# Altium schematic sheet Modified_schematic.SchDoc(Modified_schematic)
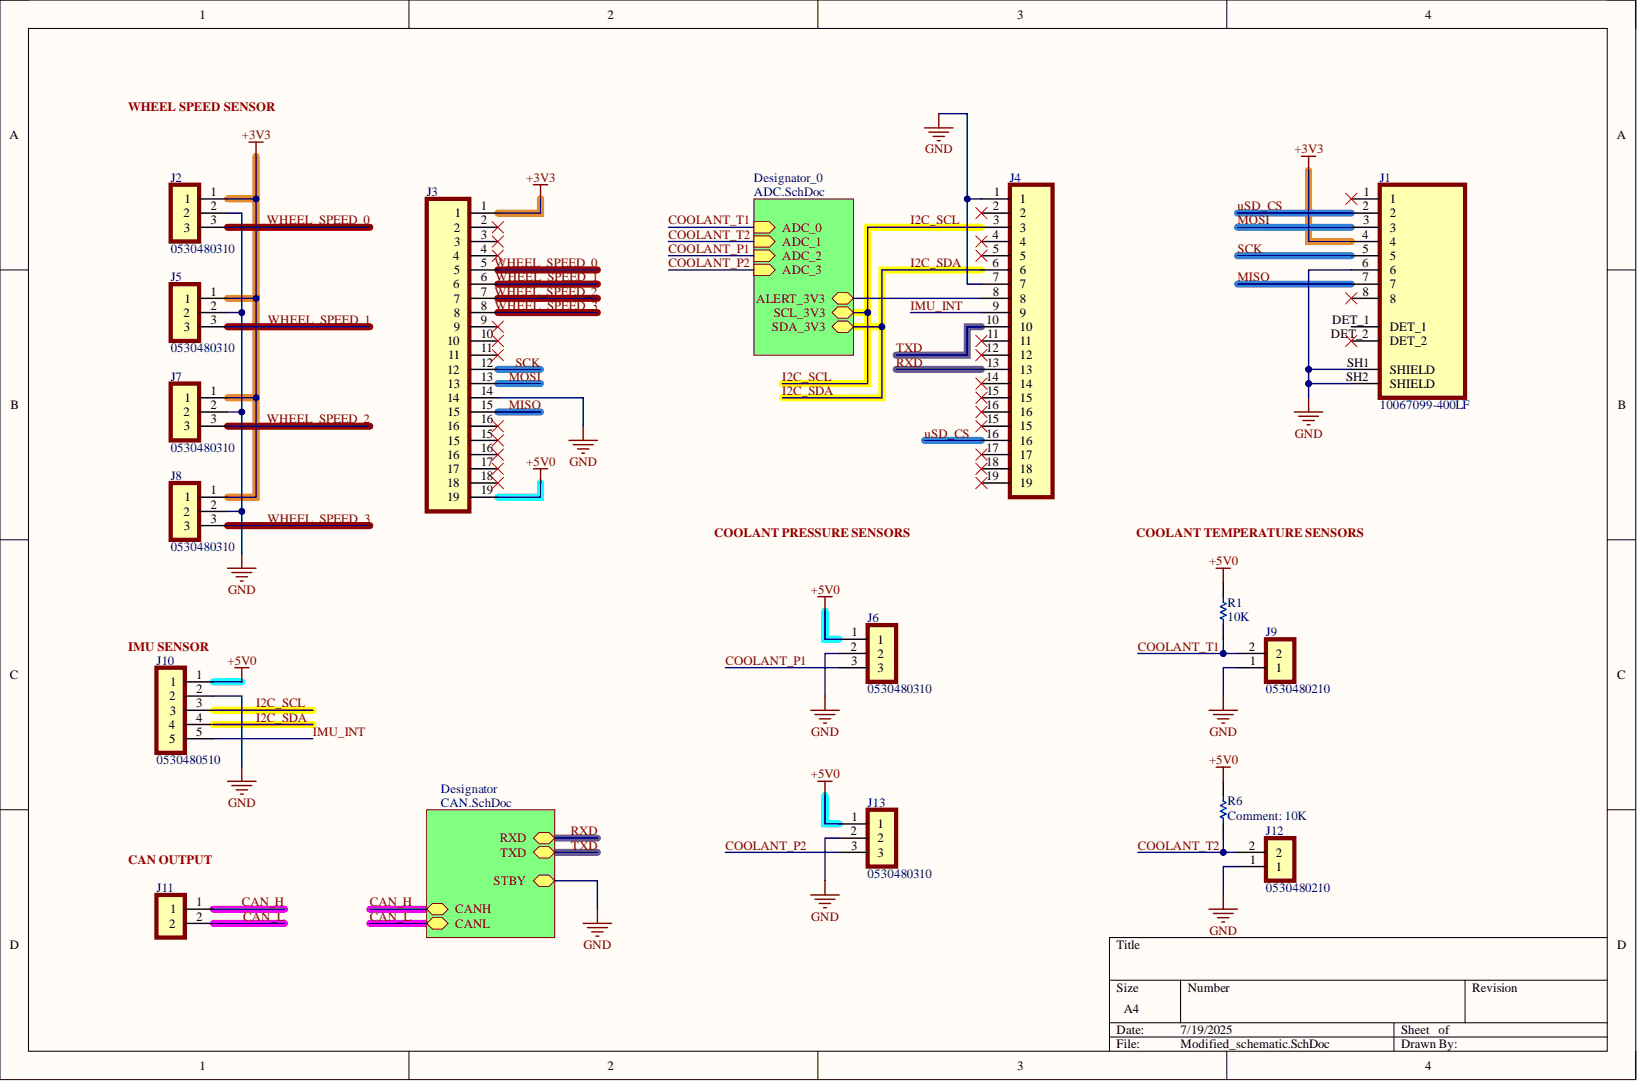
[diagram p0: Modified_schematic.SchDoc]

## Parts
J1  10067099-400LF
J2  0530480310
J3  PPTC191LFBN-RC  (value not drawn)
J4  PPTC191LFBN-RC  (value not drawn)
J5  0530480310
J6  0530480310
J7  0530480310
J8  0530480310
J9  0530480210
J10  0530480510
J11  0530480210
J12  0530480210
J13  0530480310
R1  10K
R6  10K

## Nets
+3V3: J1.4 J2.1 J3.1 J5.1 J7.1 J8.1
+5V0: J3.19 J6.1 J10.1 J13.1 R1.2 R6.2
GND: J1.6 J1.SH1(SHIELD) J1.SH2(SHIELD) J2.2 J3.14 J4.1 J4.7 J5.2 J6.2 J7.2 J8.2 J9.1 J10.2 J12.1 J13.2
CAN_H: J11.1
CAN_L: J11.2
COOLANT_P1: J6.3
COOLANT_P2: J13.3
COOLANT_T1: J9.2 R1.1
COOLANT_T2: J12.2 R6.1
I2C_SCL: J4.3 J10.3
I2C_SDA: J4.6 J10.4
IMU_INT: J4.9 J10.5
MISO: J1.7 J3.15
MOSI: J1.3 J3.13
RXD: J4.13
SCK: J1.5 J3.12
TXD: J4.10
uSD_CS: J1.2 J4.16
WHEEL_SPEED_0: J2.3 J3.5
WHEEL_SPEED_1: J3.6 J5.3
WHEEL_SPEED_2: J3.7 J7.3
WHEEL_SPEED_3: J3.8 J8.3
NetJ1_1: J1.1
NetJ1_8: J1.8
NetJ1_DET_1: J1.DET_1
NetJ1_DET_2: J1.DET_2
NetJ3_2: J3.2
NetJ3_3: J3.3
NetJ3_4: J3.4
NetJ3_9: J3.9
NetJ3_10: J3.10
NetJ3_11: J3.11
NetJ3_15: J3.15
NetJ3_16: J3.16
NetJ3_17: J3.17
NetJ3_18: J3.18
NetJ4_2: J4.2
NetJ4_4: J4.4
NetJ4_5: J4.5
NetJ4_8: J4.8
NetJ4_11: J4.11
NetJ4_12: J4.12
NetJ4_14: J4.14
NetJ4_15: J4.15
NetJ4_16: J4.16
NetJ4_17: J4.17
NetJ4_18: J4.18
NetJ4_19: J4.19

## Library data of the parts
J1 = CONN,microSD,SMD: 10 (8 + 2) Position Card Connector Secure Digital - microSD™ Surface Mount, Right Angle Gold; Manufacturer: Amphenol ICC (FCI); Vendor Part Number: 609-10067099-400LFCT-ND; Vendor: Digikey; Component Kind: Standard; Datasheet: https://www.amphenol-cs.com/media/wysiwyg/files/drawing/10067099.pdf; Library Name: Schlib1.SchLib; Manufacturer Part Number: 10067099-400LF
J2 J5-J8 J13 = CONN HEADER R/A 3POS 1.25MM: Connector Header Through Hole, Right Angle 3 position 0.049" (1.25mm); Manufacturer: Molex; Vendor Part Number: WM1743-ND; Vendor: Digikey; Component Kind: Standard; Datasheet: https://tools.molex.com/pdm_docs/sd/530480210_sd.pdf; Library Name: Schlib1.SchLib; Manufacturer Part Number: 0530480310
J3 J4 = CONN HDR 19POS 0.1 TIN PCB: 19 Position Header Connector 0.100" (2.54mm) Through Hole Tin; Manufacturer: Sullins Connector Solutions; Vendor Part Number: S7017-ND; Vendor: Digikey; Component Kind: Standard; Datasheet: https://mm.digikey.com/Volume0/opasdata/d220001/medias/docus/937/Female_Headers.100_DS.pdf; Library Name: Schlib1.SchLib; Manufacturer Part Number: PPTC191LFBN-RC
J9 J11 J12 = CONN HEADER R/A 2POS 1.25MM: Connector Header Through Hole, Right Angle 2 position 0.049" (1.25mm); Manufacturer: Molex; Vendor Part Number: WM1742-ND; Vendor: Digikey; Component Kind: Standard; Datasheet: https://tools.molex.com/pdm_docs/sd/530480210_sd.pdf; Library Name: Schlib1.SchLib; Manufacturer Part Number: 0530480210
J10 = CONN HEADER R/A 5POS 1.25MM: Connector Header Through Hole, Right Angle 5 position 0.049" (1.25mm); Manufacturer: Molex; Vendor Part Number: WM1745-ND; Vendor: Digikey; Component Kind: Standard; Datasheet: https://tools.molex.com/pdm_docs/sd/530480210_sd.pdf; Library Name: Schlib1.SchLib; Manufacturer Part Number: 0530480510
R1 R6 = RES 10K OHM 5% 1/10W 0603: 10 kOhms ±5% 0.1W, 1/10W Chip Resistor 0603 (1608 Metric) Automotive AEC-Q200 Thick Film; Manufacturer: Stackpole Electronics Inc; Manufacturer Part Number: RMCF0603JT10K0; Vendor Part Number: RMCF0603JT10K0CT-ND; Vendor: Digikey; Component Kind: Standard; Datasheet: https://www.seielect.com/catalog/sei-rmcf_rmcp.pdf; Library Name: Schlib1.SchLib
